# Altium schematic sheet RS485.SchDoc
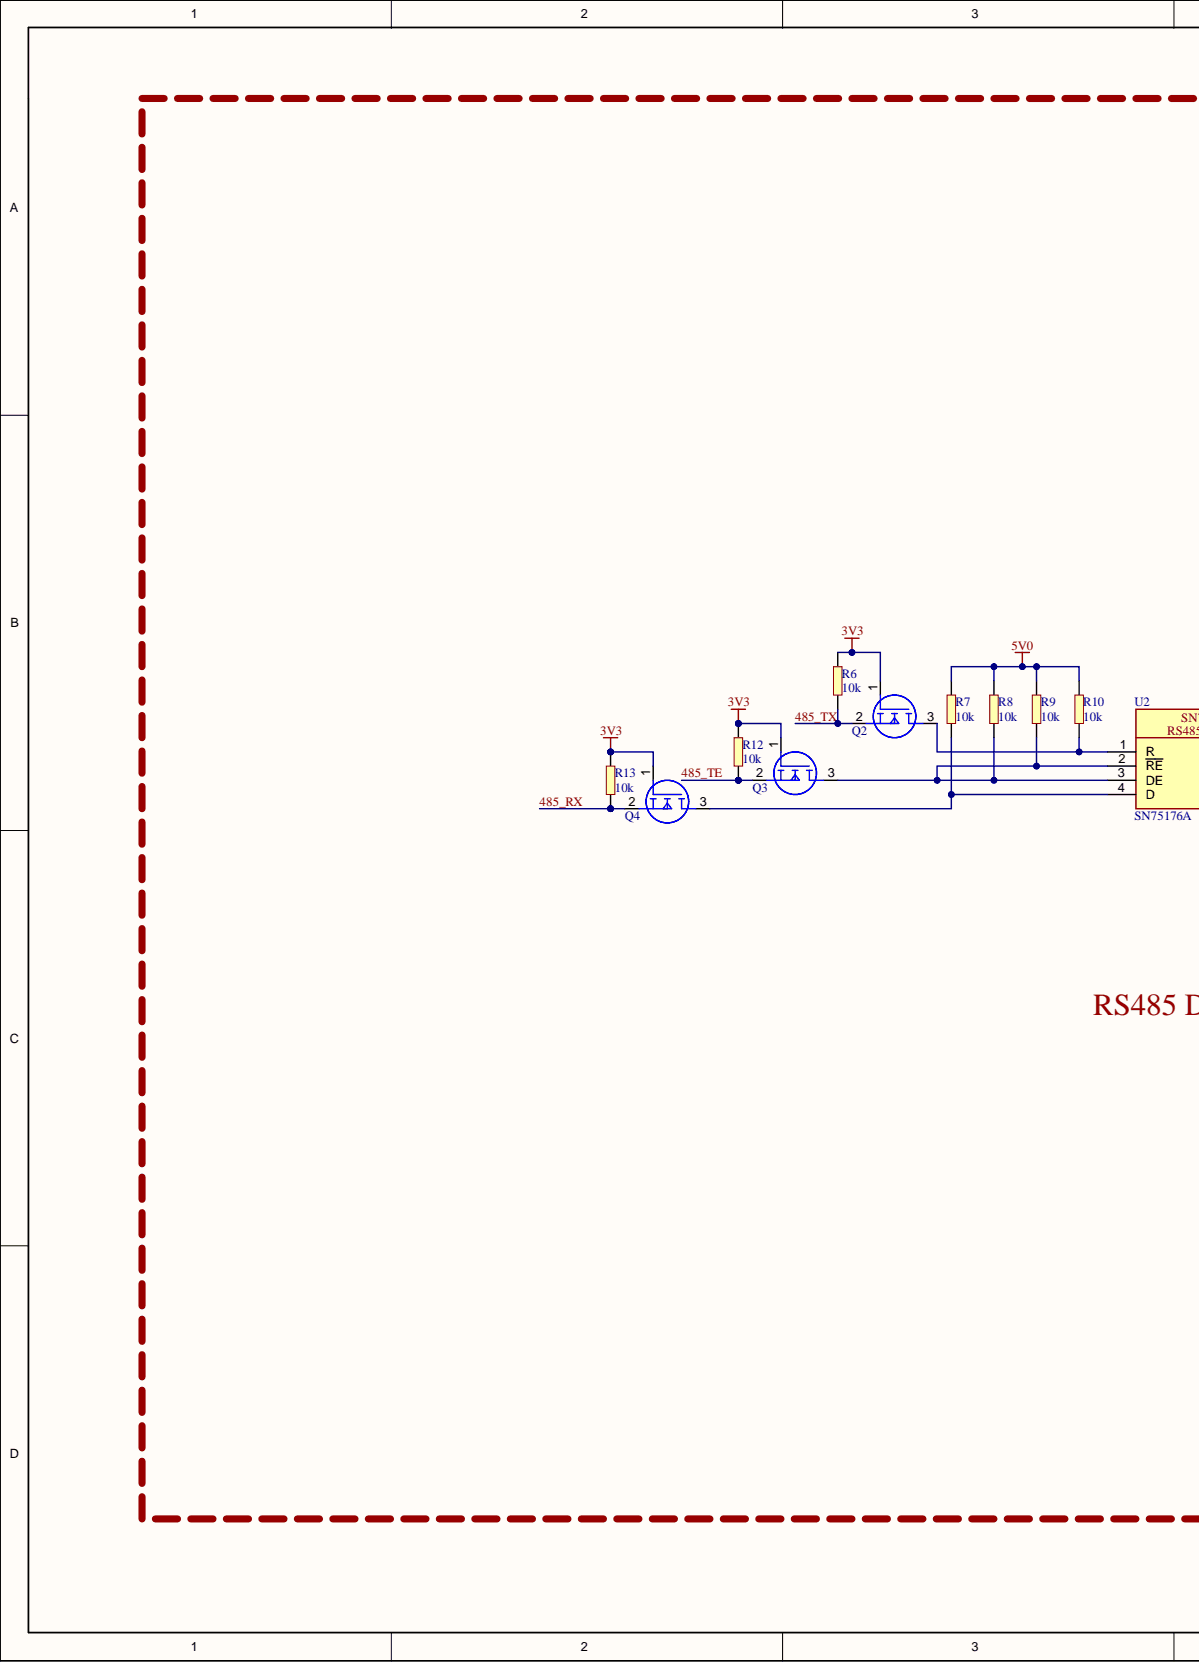
[diagram p0: RS485.SchDoc, left]
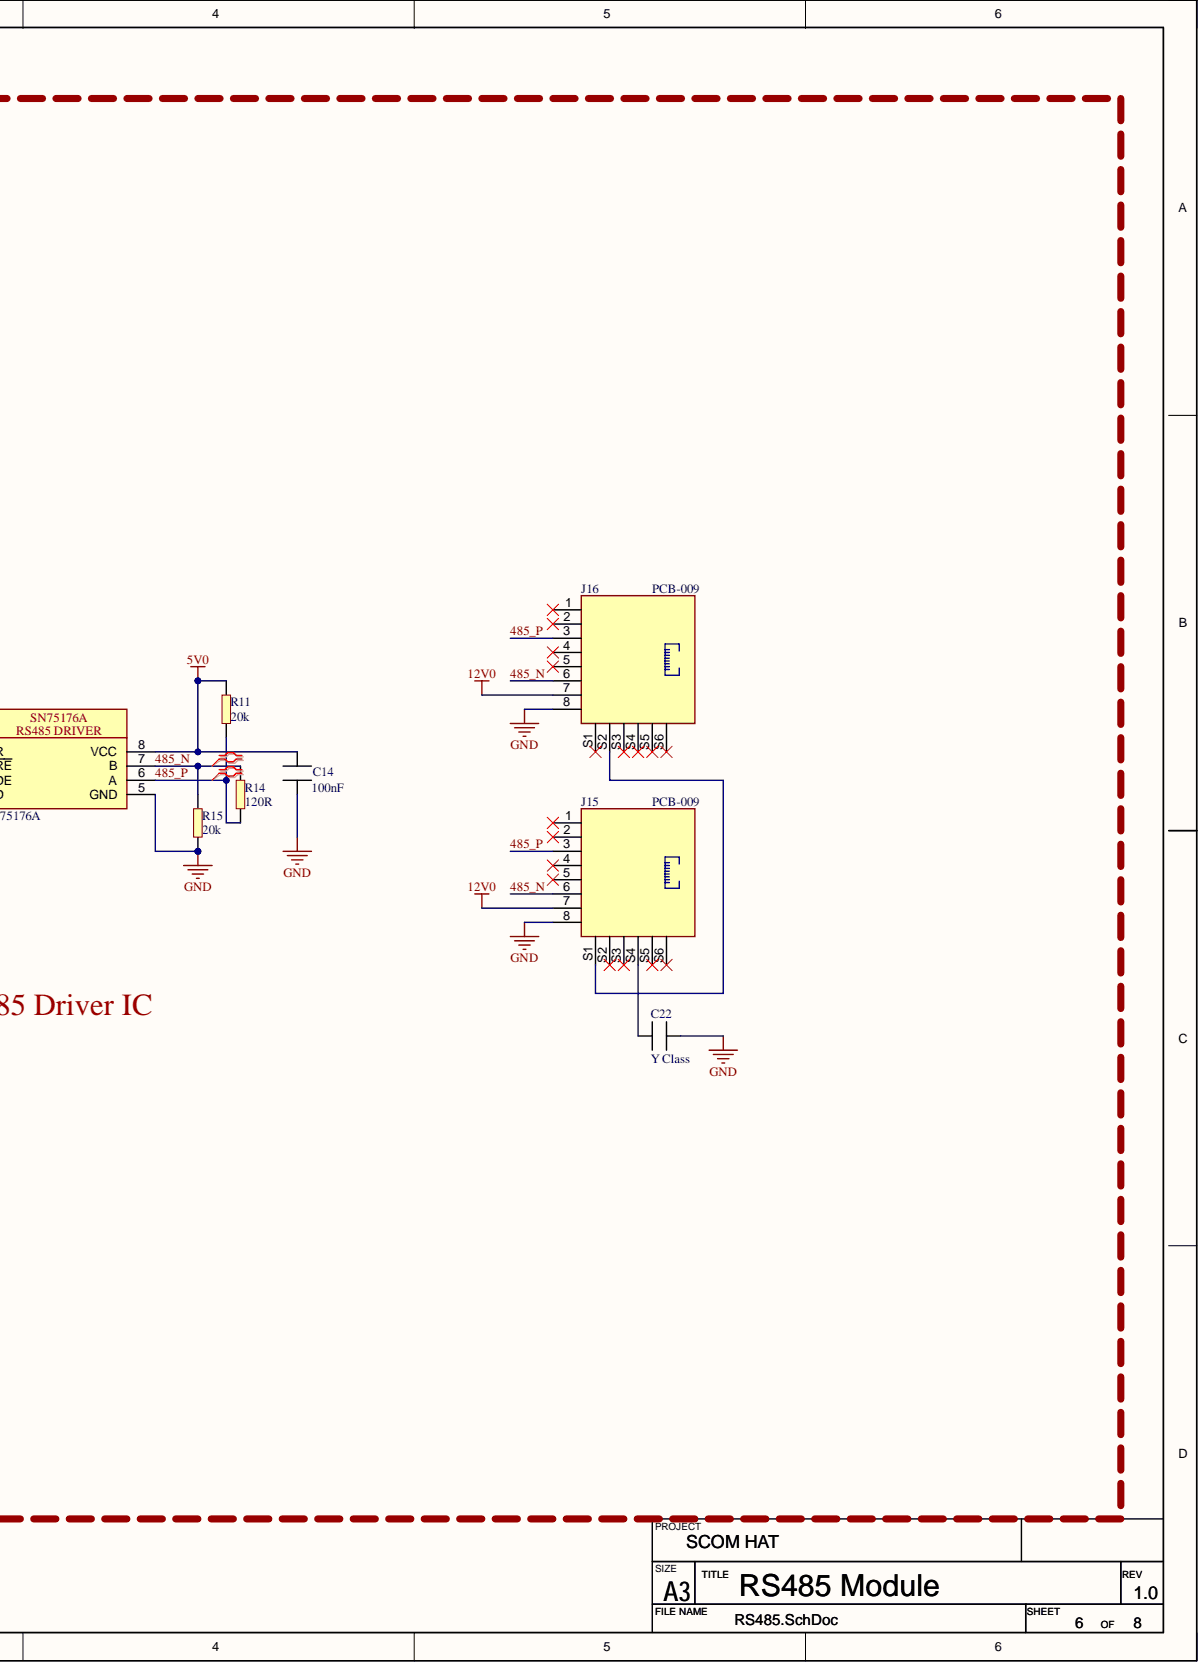
[diagram p1: RS485.SchDoc, right]

## Parts
C14  value 100nF  [CAPC2012X13L]  (hidden comment: MLCC_0805)
C22  value Y Class  [VY1222M43Y5UC63V0]  (hidden comment: VY1)  (no BOM)
J15  value PCB-009  [PCB-009]  (hidden comment: ERP_148-3)
J16  value PCB-009  [PCB-009]  (hidden comment: ERP_148-3)
Q2  BSS138L  [BSS138L]  (value not drawn)
Q3  BSS138L  [BSS138L]  (value not drawn)
Q4  BSS138L  [BSS138L]  (value not drawn)
R6  value 10k  [RES_0603]  (hidden comment: ERP_101-1)
R7  value 10k  [RES_0603]  (hidden comment: ERP_101-1)
R8  value 10k  [RES_0603]  (hidden comment: ERP_101-1)
R10  value 10k  [RES_0603]  (hidden comment: ERP_101-1)
R11  value 20k  [RES_0603]  (hidden comment: ERP_101-1)
R12  value 10k  [RES_0603]  (hidden comment: ERP_101-1)
R13  value 10k  [RES_0603]  (hidden comment: ERP_101-1)
R14  value 120R  [RES_0603]  (hidden comment: ERP_101-1)
R15  value 20k  [RES_0603]  (hidden comment: ERP_101-1)
U2  SN75176A  [SN75176A]

## Nets
3V3: Q2.1 Q3.1 Q4.1 R6.1 R12.1 R13.1
5V0: C14.1 R7.1 R8.1 R10.1 R11.1 U2.8(VCC)
12V0: J15.7 J16.7
GND: C14.2 C22.2 J15.8 J16.8 R15.2 U2.5(GND)
485_N: J15.6 J16.6 R14.1 R15.1 U2.7(B)
485_P: J15.3 J16.3 R11.2 R14.2 U2.6(A)
485_RX: Q4.2 R13.2
485_TE: Q3.2 R12.2
485_TX: Q2.2 R6.2
NetC22_1: C22.1 J15.S4
NetJ15_S1: J15.S1 J16.S2
NetQ2_3: Q2.3 R10.2 U2.1(R)
NetQ3_3: Q3.3 R8.2 U2.2(RE) U2.3(DE)
NetQ4_3: Q4.3 R7.2 U2.4(D)

Not connected: J15 1 2 4 5 S2 S3 S5 S6; J16 1 2 4 5 S1 S3 S4 S5 S6

## Library data of the parts
C14 = MLCC_0805: Chip Capacitor, 2-Leads, Body 2.00x1.25mm, IPC High Density; Component Kind: Standard; Library Name: Capacitor.SchLib; Package: 0805
C22 = VY1: Ceramic Capacitor Y5U (E) Radial, Disc; Library Name: Capacitor.SchLib
J15 J16 = RJ45_Top_Entry: PCB-009 Robu; Component Kind: Standard; Library Name: Connectors.SchLib; Package: 8P8C; Supplier 1: Robu; Supplier Part Number 1: 1883509
Q2-Q4 = BSS138L: NMOS; Manufacturer 1: ON; Manufacturer Part Number 1: BSS138LT1G; Component Kind: Standard; Library Name: MOSFET.SchLib; Package: SOT23-3; Supplier 1: Evelta; Supplier Part Number 1: 042-BSS138LT1G
R6-R8 R10-R15 = RES_0603: Manufacturer Part Number 1: 560112116003; Component Kind: Standard; Description: RES_0603; Library Name: Resistor.SchLib; Package: 0603
U2 = SN75176A: RS485/422 Driver IC; Manufacturer 1: Sunrom; Manufacturer Part Number 1: 3875; Component Kind: Standard; Library Name: Communication.SchLib; Value: SN75176A
